# Altium schematic sheet CPLD-Power.SchDoc(CPLD-Power)
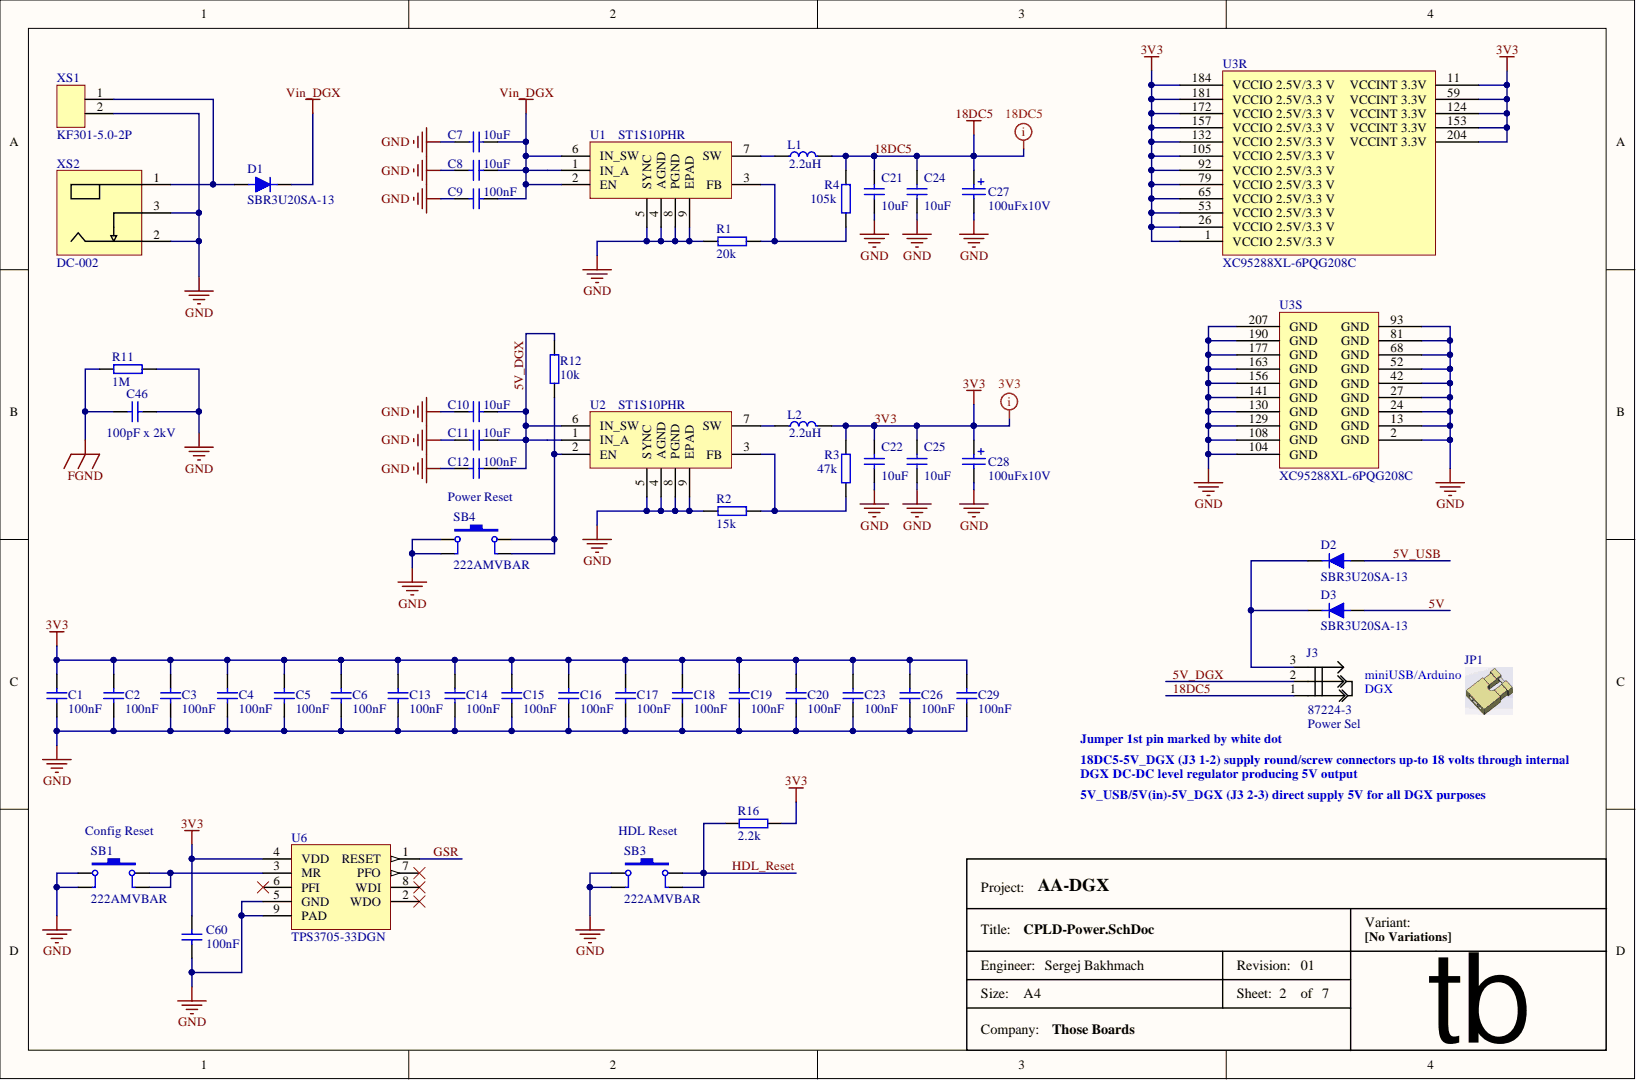
[diagram p0: CPLD-Power.SchDoc]

## Parts
C1  value 100nF  [C0603]  (hidden comment: CAP)
C2  value 100nF  [C0603]  (hidden comment: CAP)
C3  value 100nF  [C0603]  (hidden comment: CAP)
C4  value 100nF  [C0603]  (hidden comment: CAP)
C5  value 100nF  [C0603]  (hidden comment: CAP)
C6  value 100nF  [C0603]  (hidden comment: CAP)
C7  value 10uF  [C0805]  (hidden comment: CAP)
C8  value 10uF  [C0805]  (hidden comment: CAP)
C9  value 100nF  [C0603]  (hidden comment: CAP)
C10  value 10uF  [C0805]  (hidden comment: CAP)
C11  value 10uF  [C0805]  (hidden comment: CAP)
C12  value 100nF  [C0603]  (hidden comment: CAP)
C13  value 100nF  [C0603]  (hidden comment: CAP)
C14  value 100nF  [C0603]  (hidden comment: CAP)
C15  value 100nF  [C0603]  (hidden comment: CAP)
C16  value 100nF  [C0603]  (hidden comment: CAP)
C17  value 100nF  [C0603]  (hidden comment: CAP)
C18  value 100nF  [C0603]  (hidden comment: CAP)
C19  value 100nF  [C0603]  (hidden comment: CAP)
C20  value 100nF  [C0603]  (hidden comment: CAP)
C21  value 10uF  [C0805]  (hidden comment: CAP)
C22  value 10uF  [C0805]  (hidden comment: CAP)
C23  value 100nF  [C0603]  (hidden comment: CAP)
C24  value 10uF  [C0805]  (hidden comment: CAP)
C25  value 10uF  [C0805]  (hidden comment: CAP)
C26  value 100nF  [C0603]  (hidden comment: CAP)
C27  value 100uFx10V  [CAP_CASE_C]  (hidden comment: uF)
C28  value 100uFx10V  [CAP_CASE_C]  (hidden comment: uF)
C29  value 100nF  [C0603]  (hidden comment: CAP)
C46  value 100pF x 2kV  [C1210]  (hidden comment: CAP)
C60  100nF  [0603_CAPACITOR]
D1  value SBR3U20SA-13  Manufacturer Part: SBR3U20SA-13  [SMA]  (hidden comment: Diode)
D2  value SBR3U20SA-13  Manufacturer Part: SBR3U20SA-13  [SMA]  (hidden comment: Diode)
D3  value SBR3U20SA-13  Manufacturer Part: SBR3U20SA-13  [SMA]  (hidden comment: Diode)
J3  Power Sel  value 87224-3  Manufacturer Part: 87224-3  [Jumper_3pin_yellow]
JP1  VCC_sel  (value not drawn)  (mechanical)
L1  value 2.2uH  [LTF5022]  (hidden comment: L_LTF5022)
L2  value 2.2uH  [LTF5022]  (hidden comment: L_LTF5022)
R1  value 20k  [0603_RESISTOR]  (hidden comment: Resistor)
R2  value 15k  [0603_RESISTOR]  (hidden comment: Resistor)
R3  value 47k  [0603_RESISTOR]  (hidden comment: Resistor)
R4  value 105k  [0603_RESISTOR]  (hidden comment: Resistor)
R11  value 1M  [0805_resistor]  (hidden comment: Resistor)
R12  value 10k  [0603_RESISTOR]  (hidden comment: Resistor)
R16  value 2.2k  [0603_RESISTOR]  (hidden comment: Resistor)
SB1  Config Reset  value 222AMVBAR  Manufacturer Part: 222AMVBAR  [Key 6.2x6.2]
SB3  HDL Reset  value 222AMVBAR  Manufacturer Part: 222AMVBAR  [Key 6.2x6.2]
SB4  Power Reset  value 222AMVBAR  Manufacturer Part: 222AMVBAR  [Key 6.2x6.2]
U1  ST1S10  value ST1S10PHR  Manufacturer Part: ST1S10PHR  [SO8_PWR_pad]
U2  ST1S10  value ST1S10PHR  Manufacturer Part: ST1S10PHR  [SO8_PWR_pad]
U3  value XC95288XL-6PQG208C  Manufacturer Part: XC95288XL-6PQG208C  [PQ208 - XC95288XL]  (hidden comment: XC95288XL-6PQ208C)  (parts R,S)
U6  TPS3705-33DGN  [DGNR_pwrpad]
XS1  value KF301-5.0-2P  Manufacturer Part: KF301-5.0-2P  [DG301-5.0-2P]  (hidden comment: DG301-5.0-2p)
XS2  value DC-002  [PJ-002AH]  (hidden comment: PWR_JCONN_21 smd)

## Nets
FGND: C46.1 R11.1
GND: C1.1 C2.1 C3.1 C4.1 C5.1 C6.1 C7.1 C8.1 C9.2 C10.1 C11.1 C12.2 C13.1 C14.1 C15.1 C16.1 C17.1 C18.1 C19.1 C20.1 C21.1 C22.1 C23.1 C24.1 C25.1 C26.1 C27.2 C28.2 C29.1 C46.2 C60.2 R1.1 R2.1 R11.2 SB1.1 SB1.3 SB3.1 SB3.3 SB4.1 SB4.3 U1.4(AGND) U1.5(SYNC) U1.8(PGND) U1.9(EPAD) U2.4(AGND) U2.5(SYNC) U2.8(PGND) U2.9(EPAD) U3.2(GND) U3.13(GND) U3.24(GND) U3.27(GND) U3.42(GND) U3.52(GND) U3.68(GND) U3.81(GND) U3.93(GND) U3.104(GND) U3.108(GND) U3.129(GND) U3.130(GND) U3.141(GND) U3.156(GND) U3.163(GND) U3.177(GND) U3.190(GND) U3.207(GND) U6.5(GND) U6.9(PAD) XS1.2 XS2.2 XS2.3
VIN_DGX: C7.2 C8.2 C9.1 D1.2 U1.1(IN_A) U1.2(EN) U1.6(IN_SW)
3V3: C1.2 C2.2 C3.2 C4.2 C5.2 C6.2 C13.2 C14.2 C15.2 C16.2 C17.2 C18.2 C19.2 C20.2 C22.2 C23.2 C25.2 C26.2 C28.1 C29.2 C60.1 L2.2 R3.1 R16.1 U3.1(VCCIO_2.5V/3.3_V) U3.11(VCCINT_3.3V) U3.26(VCCIO_2.5V/3.3_V) U3.53(VCCIO_2.5V/3.3_V) U3.59(VCCINT_3.3V) U3.65(VCCIO_2.5V/3.3_V) U3.79(VCCIO_2.5V/3.3_V) U3.92(VCCIO_2.5V/3.3_V) U3.105(VCCIO_2.5V/3.3_V) U3.124(VCCINT_3.3V) U3.132 U3.153(VCCINT_3.3V) U3.157 U3.172 U3.181 U3.184 U3.204(VCCINT_3.3V) U6.4(VDD)
5V: D3.1
5V_DGX: C10.2 C11.2 C12.1 J3.2 R12.2 U2.1(IN_A) U2.6(IN_SW)
5V_USB: D2.1
18DC5: C21.2 C24.2 C27.1 J3.1 L1.2 R4.1
GSR: U6.1(RESET)
HDL_RESET: R16.2 SB3.2 SB3.4
NetD1_1: D1.1 XS1.1 XS2.1
NetD2_2: D2.2 D3.2 J3.3
NetL1_1: L1.1 U1.7(SW)
NetL2_1: L2.1 U2.7(SW)
NetR1_2: R1.2 R4.2 U1.3(FB)
NetR2_2: R2.2 R3.2 U2.3(FB)
NetR12_1: R12.1 SB4.2 SB4.4 U2.2(EN)
NetSB1_2: SB1.2 SB1.4 U6.3(MR)

Not connected: U6 2(WDO) 6(PFI) 7(PFO) 8(WDI)

## Library data of the parts
C1-C6 C9 C12-C20 C23 C26 = CAP: Manufacturer: Vishay / Vitramon; Manufacturer Part: VJ0603Y104JXJPW1BC; Type of Component: Ceramic Capacitor; Component Kind: Standard; Manufacturer Link: http://www.vishay.com/product?docid=28548; Package / Case: 0603; Supplier: mouser; Supplier Link: http://www.mouser.com/ProductDetail/Vishay/VJ0603Y104JXJPW1BC/?qs=%2fha2pyFaduidGoO0X7aK7Xgs%2fjxfhnLiK6SRw4Lz0%252bZK3PJ8DddTrQ%3d%3d; Supplier Part: 77-VJ0603Y104JXJPBC; Library Name: RPI-VITA.IntLib
C7 C8 C10 C11 C21 C22 C24 C25 = CAP: Manufacturer: Murata; Manufacturer Part: GRM21BC81C106KE15L; Type of Component: Ceramic Capacitor; Component Kind: Standard; Manufacturer Link: http://psearch.en.murata.com/capacitor/result/smd/?status=all&pid=GRM21BC81C106KE15L; Package / Case: 0805; Supplier: mouser; Supplier Link: http://www.mouser.com/ProductDetail/Murata/GRM21BC81C106KE15L/?qs=%2fha2pyFadugq0yC%2fv5omHdWffW%252bX1z%252bEjQXmyIECJNTTTKySHodREy42G6WZAHxu; Supplier Part: 81-GRM21BC81C106KE5L; Library Name: RPI-VITA.IntLib
C27 C28 = Cap_pol_tant: Manufacturer: AVX; Manufacturer Part: TPSC107K010S0075; Type of Component: Tantalum Capacitor; Component Kind: Standard; Manufacturer Link: http://www.avx.com/prodinfo_productdetail.asp?I=82&K=search&C=&word=TPSC107K010S0075&form=number&Number=; Package / Case: Case C; Supplier: mouser; Supplier Link: http://www.mouser.ee/ProductDetail/AVX/TPSC107K010S0075/?qs=sGAEpiMZZMuEN2agSAc2pj5RGSp5%252bR392wzwmTGvCpg%3d; Supplier Part: 581-TPSC107K010S0075; Library Name: RPI-VITA.IntLib
C29 = CAP: Manufacturer: Vishay / Vitramon; Manufacturer Part: VJ0603Y104JXJPW1BC; Type of Component: Caramic Capacitor; Component Kind: Standard; Manufacturer Link: http://www.vishay.com/product?docid=28548; Package / Case: 0603; Supplier: mouser; Supplier Link: http://www.mouser.com/ProductDetail/Vishay/VJ0603Y104JXJPW1BC/?qs=%2fha2pyFaduidGoO0X7aK7Xgs%2fjxfhnLiK6SRw4Lz0%252bZK3PJ8DddTrQ%3d%3d; Supplier Part: 77-VJ0603Y104JXJPBC; Library Name: RPI-VITA.IntLib
C46 = CAP: Manufacturer: Murata Electronics; Manufacturer Part: GRM32A7U3D101JW31D; Type of Component: Ceramic Capacitor; Component Kind: Standard; Manufacturer Link: http://psearch.en.murata.com/capacitor/product/GRM32A7U3D101JW31%23.html; Package / Case: 1210; Supplier: mouser; Supplier Link: http://www.mouser.ee/ProductDetail/Murata-Electronics/GRM32A7U3D101JW31D/?qs=sGAEpiMZZMs0AnBnWHyRQFQYdTDrJniYFw4lyPz2MF4%3d; Supplier Part: 81-GRM32A7U3D101JW31; Library Name: RPI-VITA.IntLib
C60 = cap_0603: Manufacturer: Vishay / Vitramon; Manufacturer Part: VJ0603Y104JXJPW1BC; Type of Component: Ceramic Capacitor; Component Kind: Standard; Manufacturer Link: http://www.vishay.com/product?docid=28548; Package / Case: 0603; Supplier: mouser; Supplier Link: http://www.mouser.com/ProductDetail/Vishay/VJ0603Y104JXJPW1BC/?qs=%2fha2pyFaduidGoO0X7aK7Xgs%2fjxfhnLiK6SRw4Lz0%252bZK3PJ8DddTrQ%3d%3d; Supplier Part: 77-VJ0603Y104JXJPBC; Value: 100nF; Library Name: RPI-VITA.IntLib
D1-D3 = Diode: Schottky Diodes & Rectifiers; Manufacturer: Diodes Incorporated; Component Kind: Standard; Manufacturer Link: http://www.diodes.com/search?type=0&value=SBR3U20SA-13; Package / Case: SMA; Supplier: mouser; Supplier Link: http://www.mouser.ee/ProductDetail/Diodes-Incorporated/SBR3U20SA-13/?qs=sGAEpiMZZMtQ8nqTKtFS%2fE6cG%252brOIQfRLc3x%2fXaLBa0%3d; Supplier Part: 621-SBR3U20SA-13; Type of Component: Diode; Library Name: RPI-VITA.IntLib
J3 = jumper_3pin: Manufacturer: TE Connectivity / AMP; Type of Component: Header 1x3; Component Kind: Standard; Manufacturer Link: http://www.te.com/usa-en/product-87224-3.html#pdp-related-products-inner; Package / Case: Header 1 x 3; Supplier: mouser; Supplier Link: http://www.mouser.ee/ProductDetail/TE-Connectivity-AMP/87224-3/?qs=sGAEpiMZZMs%252bGHln7q6pm48SVpWlpfsErguO%2fp0873w%3d; Supplier Part: 571-872243; Library Name: RPI-VITA.IntLib
JP1 = jumper yellow: Manufacturer: Great Electric; Manufacturer Part: Jumper Cap Shunts Short Circuit Cap; Package / Case: 2.54mm; Supplier: aliexpress.com; Supplier Link: http://www.aliexpress.com/item/500pcs-lot-Asssorted-Color-2-54mm-Standard-Circuit-Board-Jumper-Cap-Shunts-Short-Circuit-Cap-CGKCH119/32344305619.html?spm=2114.03010208.3.80.nPPmoy&ws_ab_test=searchweb201556_1,searchweb201644_1_505_506_503_504_502_10001_10002_10017_10010_10005_10011_10006_10003_10004_10009_10007_10008,searchweb201560_5,searchweb1451318400_-1,searchweb1451318411_6452&btsid=16d11777-edde-4755-b8b5-9adacb495b09; Supplier Part: Jumper; Type of Component: Jumper Yellow; Value: Jumper Yellow; Library Name: RPI-VITA.IntLib
L1 L2 = L_LTF5022: Manufacturer: TDK; Manufacturer Part: LTF5022T-2R2N3R2-LC; Type of Component: Inductor; Component Kind: Standard; Manufacturer Link: http://product.tdk.com/inductor/ind/detailed_information.php?lang=en&part_no=LTF5022T-2R2N3R2-LC; Package / Case: 5.2 mm x 5 mm; Supplier: mouser; Supplier Link: http://www.mouser.ee/search/ProductDetail.aspx?TDK%2fLTF5022T-2R2N3R2-LC%2f&qs=%2fha2pyFadui2dkPtc8Qb7GgS2MXg2uuOAtrBGixOx605UNMElKnYgkaM4wRA6%2fNS; Supplier Part: 810-LTF5022T2R2N3R2L; Library Name: RPI-VITA.IntLib
R1 = Resistor: Manufacturer: Vishay / Dale; Manufacturer Part: CRCW060320K0FKEA; Component Kind: Standard; Manufacturer Link: http://www.vishay.com/product?docid=20035; Package / Case: 0603; Supplier: mouser; Supplier Link: http://www2.mouser.com/ProductDetail/Vishay-Dale/CRCW060320K0FKEA/?qs=sGAEpiMZZMvdGkrng054tzs1OVvhhRzmqGSefucNZhs%3d; Supplier Part: 71-CRCW0603-20K-E3; Type of Component: Resistor; Library Name: RPI-VITA.IntLib
R2 = Resistor: Manufacturer: Vishay / Dale; Manufacturer Part: CRCW060315K0FKEA; Component Kind: Standard; Manufacturer Link: http://www.vishay.com/product?docid=20035; Package / Case: 0603; Supplier: mouser; Supplier Link: http://www2.mouser.com/ProductDetail/Vishay-Dale/CRCW060315K0FKEA/?qs=sGAEpiMZZMvdGkrng054tzs1OVvhhRzmFqyUoR13SvQ%3d; Supplier Part: 71-CRCW0603-15K-E3; Type of Component: Resistor; Library Name: RPI-VITA.IntLib
R3 = Resistor: Manufacturer: Vishay / Dale; Manufacturer Part: CRCW060347K0FKEA; Component Kind: Standard; Manufacturer Link: http://www.vishay.com/product?docid=20035; Package / Case: 0603; Supplier: mouser; Supplier Link: http://www2.mouser.com/ProductDetail/Vishay-Dale/CRCW060347K0FKEA/?qs=sGAEpiMZZMvdGkrng054t4HRUGKGWf0J%252bEfP0bj7%252bjU%3d; Supplier Part: 71-CRCW0603-47K-E3; Type of Component: Resistor; Library Name: RPI-VITA.IntLib
R4 = Resistor: Manufacturer: Vishay / Dale; Manufacturer Part: CRCW0603105KFKEA; Component Kind: Standard; Manufacturer Link: http://www.vishay.com/product?docid=20035; Package / Case: 0603; Supplier: mouser; Supplier Link: http://www2.mouser.com/ProductDetail/Vishay-Dale/CRCW0603105KFKEA/?qs=sGAEpiMZZMvdGkrng054tw79ugaueG8BiPYssBAGfpI%3d; Supplier Part: 71-CRCW0603-105K-E3; Type of Component: Resistor; Library Name: RPI-VITA.IntLib
R11 = Resistor: Manufacturer: Vishay / Dale; Manufacturer Part: CRCW08051M00FKEA; Component Kind: Standard; Manufacturer Link: http://www.vishay.com/product?docid=20035; Package / Case: 0805; Supplier: mouser; Supplier Link: http://www2.mouser.com/ProductDetail/Vishay-Dale/CRCW08051M00FKEA/?qs=sGAEpiMZZMvdGkrng054txEw7b1YnvGubiAZzjveInE%3d; Supplier Part: 71-CRCW0805-1.0M-E3; Type of Component: Resistor; Library Name: RPI-VITA.IntLib
R12 = Resistor: Manufacturer: Vishay / Dale; Manufacturer Part: CRCW060310K0FKEA; Component Kind: Standard; Manufacturer Link: http://www.vishay.com/product?docid=20035; Package / Case: 0603; Supplier: mouser; Supplier Link: http://www.mouser.com/ProductDetail/Vishay-Dale/CRCW060310K0FKEA/?qs=sGAEpiMZZMu61qfTUdNhGyptJL5EcUtREGTsEKG7Lak%3d; Supplier Part: 71-CRCW0603-10K-E3; Type of Component: Resistor; Library Name: RPI-VITA.IntLib
R16 = Resistor: Manufacturer: Vishay / Dale; Manufacturer Part: CRCW06032K20JNEA; Component Kind: Standard; Manufacturer Link: http://www.vishay.com/product?docid=20035; Package / Case: 0603; Supplier: mouser; Supplier Link: http://www.mouser.com/ProductDetail/Vishay-Dale/CRCW06032K20JNEA/?qs=sGAEpiMZZMvdGkrng054txEw7b1YnvGuRJLBQI6feSk%3d; Supplier Part: 71-CRCW0603J-2.2K-E3; Type of Component: Resistor; Library Name: RPI-VITA.IntLib
SB1 SB3 SB4 = SB_Tactile: Tactile Switches; Manufacturer: E-Switch; Type of Component: Tact Switch; Component Kind: Standard; Package / Case: 6.2 x 6.2 x 5; Supplier: mouser; Supplier Link: http://www.mouser.ee/ProductDetail/CTS-Electronic-Components/222AMVBAR/?qs=sGAEpiMZZMsgGjVA3toVBB5GWLGpesfgV6sVMBFzGDw%3d; Supplier Part: 774-222AMVBAR; Library Name: RPI-VITA.IntLib
U1 U2 = ST1S10: Manufacturer: STMicroelectronics; Type of Component: Voltage Regulator; Component Kind: Standard; Manufacturer Link: http://www.st.com/web/en/catalog/sense_power/FM142/CL1456/SC355/PF188180?s_searchtype=keyword; Package / Case: SOIC-8; Supplier: mouser; Supplier Link: http://www.mouser.com/ProductDetail/STMicroelectronics/ST1S10PHR/?qs=sGAEpiMZZMvMXbh32ZmHAKWWuRxuuGix; Supplier Part: 511-ST1S10PHR; Library Name: RPI-VITA.IntLib
U3 = XC95288XL-6PQ208C: FastFLASH XC900XL, 3.3V High-Performance CPLD with 288 Macrocells, 208-Pin PQFP, Commercial Grade; Manufacturer: Xilinx; Code_JEDEC: MS-029-FA-1; Component Kind: Standard; ComponentLink1Description: Manufacturer Link; ComponentLink1URL: http://www.xilinx.com/products/silicon_solutions/cplds/xc9500_series/xc9500xl/index.htm; ComponentLink2Description: Datasheet; ComponentLink2URL: http://direct.xilinx.com/bvdocs/publications/ds055.pdf; DatasheetVersion: ver2.0, Mar-2006; LatestRevisionDate: 13-Apr-2006; LatestRevisionNote: IPC-7351 Footprint Added.; Manufacturer Link: http://www.xilinx.com/products/silicon_solutions/cplds/xc9500_series/xc9500xl/index.htm; Package / Case: PQ-208; PackageDescription: 208-Pin Plastic Quad Flat Pack (PQ208); PackageReference: PQ208; PackageVersion: ver1.2, Jun-2004; Published: 17-Jul-2002; Supplier: Digi-Key; Supplier Link: http://www.digikey.com/product-search/en?pv459=17&FV=142c032d&k=XC95288XL&mnonly=0&newproducts=0&ColumnSort=0&page=1&quantity=0&ptm=0&fid=0&pageSize=25; Supplier Part: XC95288XL-6PQG208C-ND; Type of Component: CPLD; Library Name: RPI-VITA.IntLib; Publisher: Altium Limited
U6 = TPS3705DGNR: Processor supervisory circuits with power-fail; Manufacturer: TI; Component Kind: Standard; Manufacturer Link: http://www.ti.com/product/TPS3705-33?CMP=conv-poasamples#samplebuy; Manufacturer Part: TPS3705-33DGN; Package / Case: MSOP-8; Supplier: mouser; Supplier Link: http://www.mouser.ee/search/ProductDetail.aspx?Texas-Instruments%2fTPS3705-33DGN%2f&qs=6gY4t2uohMxyZA1EcEsrJg%3d%3d; Supplier Part: 595-TPS3705-33DGN; Type of Component: Supervisor; Value: TPS3705-33DGN; Library Name: RPI-VITA.IntLib
XS1 = DG301-5.0-2p: Type of Component: Terminal Block; Component Kind: Standard; Package / Case: KF301-5.0-2P; Supplier: aliexpress.com; Supplier Link: aliexpress.com/item/FREE-SHIPPING-100PCS-KF301-2P-KF301-5-0-2P-KF301-Screw-2Pin-5-0mm-Straight/1686434041.html?spm=2114.03020208.3.30.kyWH6u&ws_ab_test=searchweb201556_1,searchweb201644_1_10001_10002_10005_301_10006_10003_10004_62_10007,searchweb201560_6,searchweb1451318400_6150; Supplier Part: KF301-5.0-2P; Library Name: RPI-VITA.IntLib
XS2 = PWR_JCONN_21 smd: DC Power Jack 2.1mm smd; Type of Component: DC Plug; Component Kind: Standard; Supplier: aliexpress.com; Supplier Link: http://aliexpress.com/item/100PCS-Copper-SMD-5-5MM-2-1mm-DC-Socket-2-1MM-DC-Plug-PCB-Panel-Mount/32226428004.html?spm=2114.03020208.3.204.JEPBAQ&ws_ab_test=searchweb201556_1,searchweb201644_1_79_78_77_82_83_80_62_81,searchweb201560_5; Library Name: RPI-VITA.IntLib
